# Altium schematic sheet LED_Driver.SchDoc
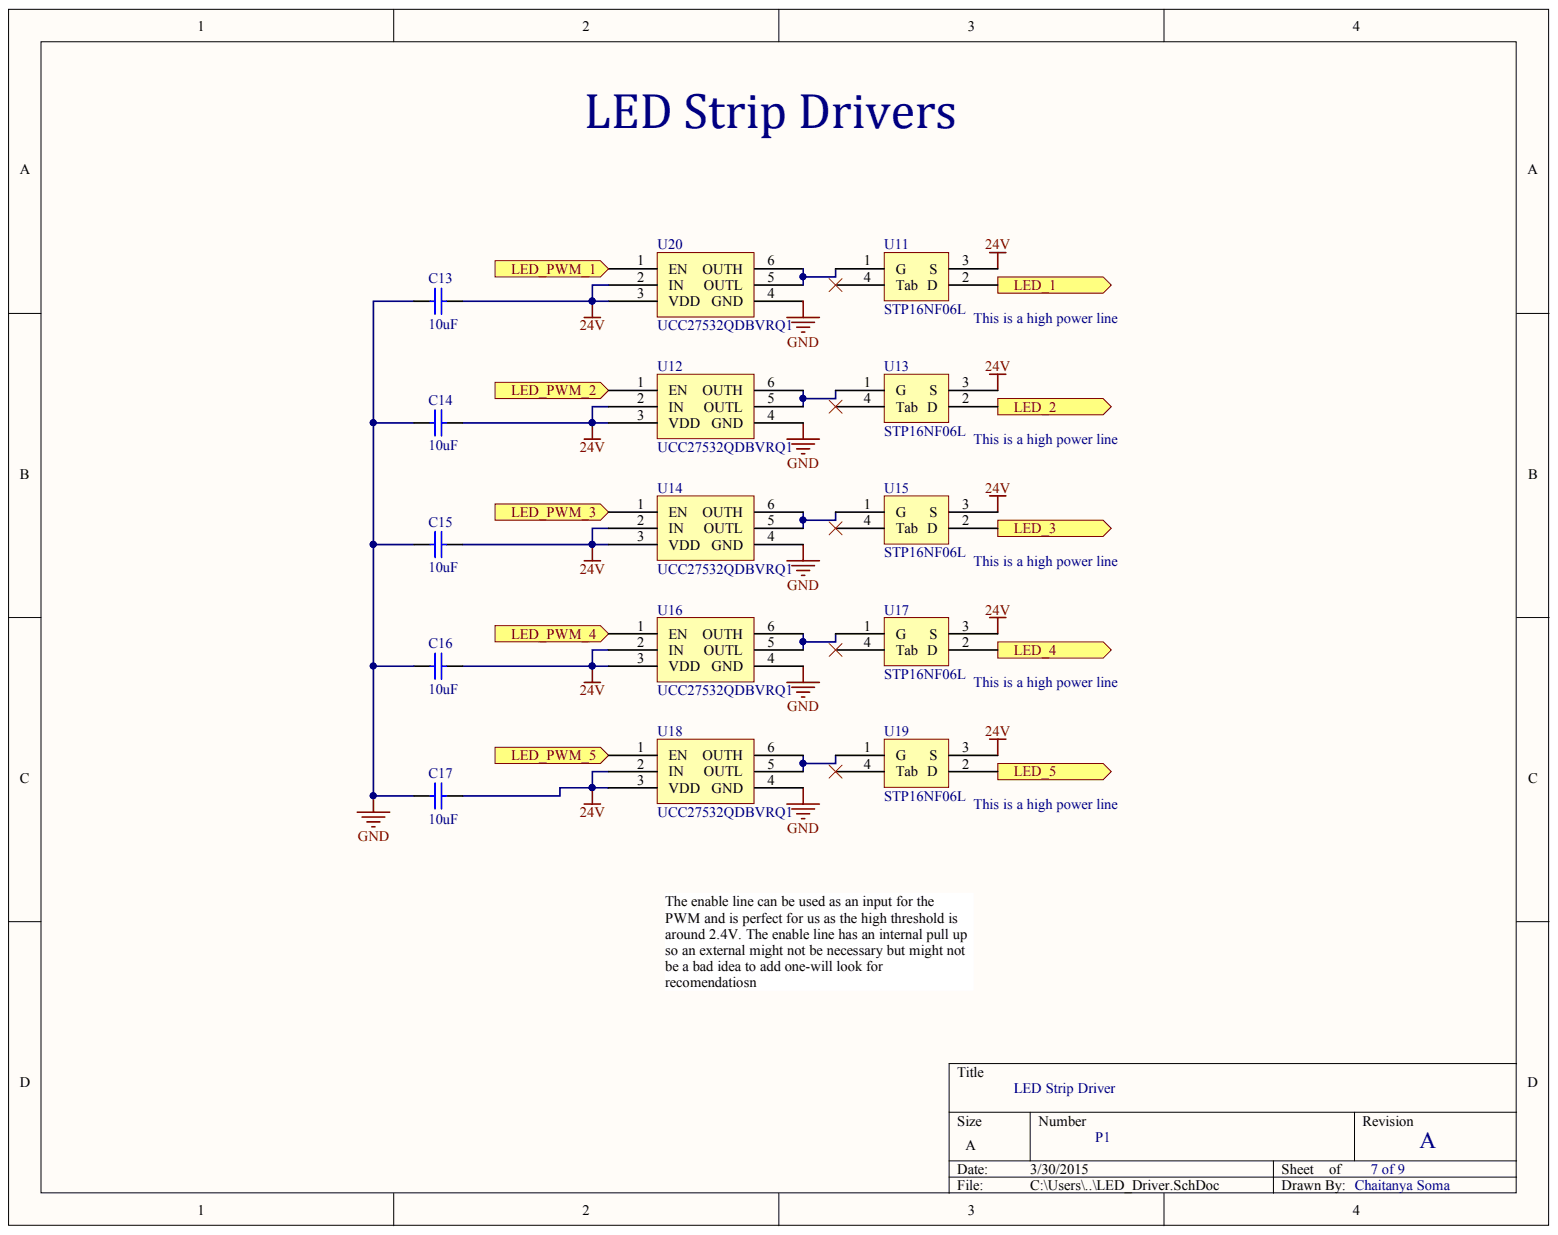
[diagram p0: LED_Driver.SchDoc]

## Parts
C13  value 10uF  [1608[0603]]  (hidden comment: Cap Semi)
C14  value 10uF  [1608[0603]]  (hidden comment: Cap Semi)
C15  value 10uF  [1608[0603]]  (hidden comment: Cap Semi)
C16  value 10uF  [1608[0603]]  (hidden comment: Cap Semi)
C17  value 10uF  [1608[0603]]  (hidden comment: Cap Semi)
U11  STP16NF06L  [STP16NF06L]
U12  UCC27532QDBVRQ1  [UCC27532QDBVRQ1]
U13  STP16NF06L  [STP16NF06L]
U14  UCC27532QDBVRQ1  [UCC27532QDBVRQ1]
U15  STP16NF06L  [STP16NF06L]
U16  UCC27532QDBVRQ1  [UCC27532QDBVRQ1]
U17  STP16NF06L  [STP16NF06L]
U18  UCC27532QDBVRQ1  [UCC27532QDBVRQ1]
U19  STP16NF06L  [STP16NF06L]
U20  UCC27532QDBVRQ1  [UCC27532QDBVRQ1]

## Ports
LED_1, LED_2, LED_3, LED_4, LED_5, LED_PWM_1, LED_PWM_2, LED_PWM_3, LED_PWM_4, LED_PWM_5

## Nets
24V: C13.1 C14.1 C15.1 C16.1 C17.1 U11.3(S) U12.2(IN) U12.3(VDD) U13.3(S) U14.2(IN) U14.3(VDD) U15.3(S) U16.2(IN) U16.3(VDD) U17.3(S) U18.2(IN) U18.3(VDD) U19.3(S) U20.2(IN) U20.3(VDD)
GND: C13.2 C14.2 C15.2 C16.2 C17.2 U12.4(GND) U14.4(GND) U16.4(GND) U18.4(GND) U20.4(GND)
LED_1: U11.2(D) ; port LED_1
LED_2: U13.2(D) ; port LED_2
LED_3: U15.2(D) ; port LED_3
LED_4: U17.2(D) ; port LED_4
LED_5: U19.2(D) ; port LED_5
LED_PWM_1: U20.1(EN) ; port LED_PWM_1
LED_PWM_2: U12.1(EN) ; port LED_PWM_2
LED_PWM_3: U14.1(EN) ; port LED_PWM_3
LED_PWM_4: U16.1(EN) ; port LED_PWM_4
LED_PWM_5: U18.1(EN) ; port LED_PWM_5
NetU11_1: U11.1(G) U20.5(OUTL) U20.6(OUTH)
NetU12_5: U12.5(OUTL) U12.6(OUTH) U13.1(G)
NetU14_5: U14.5(OUTL) U14.6(OUTH) U15.1(G)
NetU16_5: U16.5(OUTL) U16.6(OUTH) U17.1(G)
NetU18_5: U18.5(OUTL) U18.6(OUTH) U19.1(G)

Not connected: U11 4(Tab); U13 4(Tab); U15 4(Tab); U17 4(Tab); U19 4(Tab)

## Library data of the parts
C13-C17 = Cap Semi: Capacitor (Semiconductor SIM Model); Component Cost: 0.30000; Component Link Descriptions: CAP CER 10UF 16V 20% X5R 0603; Component Kind: Standard; Component Link URL: http://www.digikey.com/product-detail/en/GRM188R61C106MA73D/490-7201-1-ND/3900482; Digikey PN: 490-7201-1-ND; LatestRevisionDate: 29-May-2009; LatestRevisionNote: IPC-7351 Footprint Added.; Library Name: Miscellaneous Devices.IntLib; PackageDescription: Chip Capacitor; PackageReference: C1206; Published: 8-Jun-2000; Signal Integrity: Cap Semi; Simulation: CAP; Publisher: Altium Limited
U11 U13 U15 U17 U19 = STP16NF06L: MOSFET N-CH 60V 16A TO-220; Component Cost: 0.99; Component Kind: Standard; Component Link Descriptions: MOSFET N-CH 60V 16A TO-220; Component Link URL: http://www.digikey.com/product-detail/en/STP16NF06L/497-2765-5-ND/603790; Digikey PN: 497-2765-5-ND; Library Name: Power.SchLib
U12 U14 U16 U18 U20 = UCC27532QDBVRQ1: IC IGBT GATE DRIVER SOT-23-6; Component Cost: 2.52000; Component Kind: Standard; Component Link Descriptions: IC IGBT GATE DRIVER SOT-23-6; Component Link URL: http://www.digikey.com/product-detail/en/UCC27532QDBVRQ1/296-38321-1-ND/4967526; Digikey PN: 296-38321-1-ND; Library Name: Power.SchLib
